# Altium schematic sheet ECU-3.SchDoc
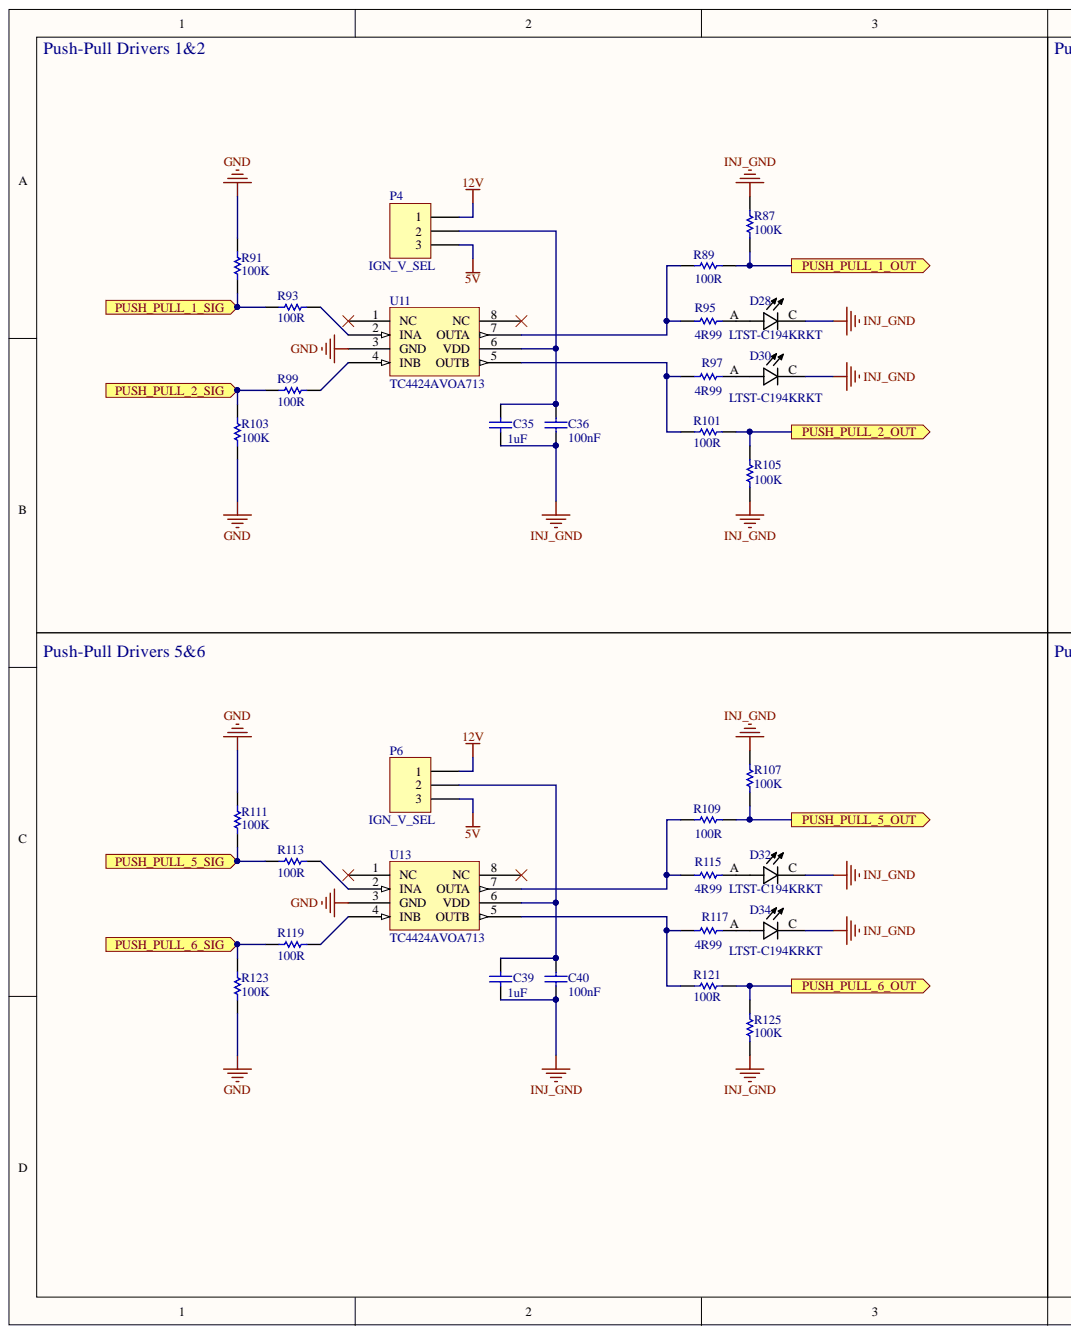
[diagram p0: ECU-3.SchDoc, left]
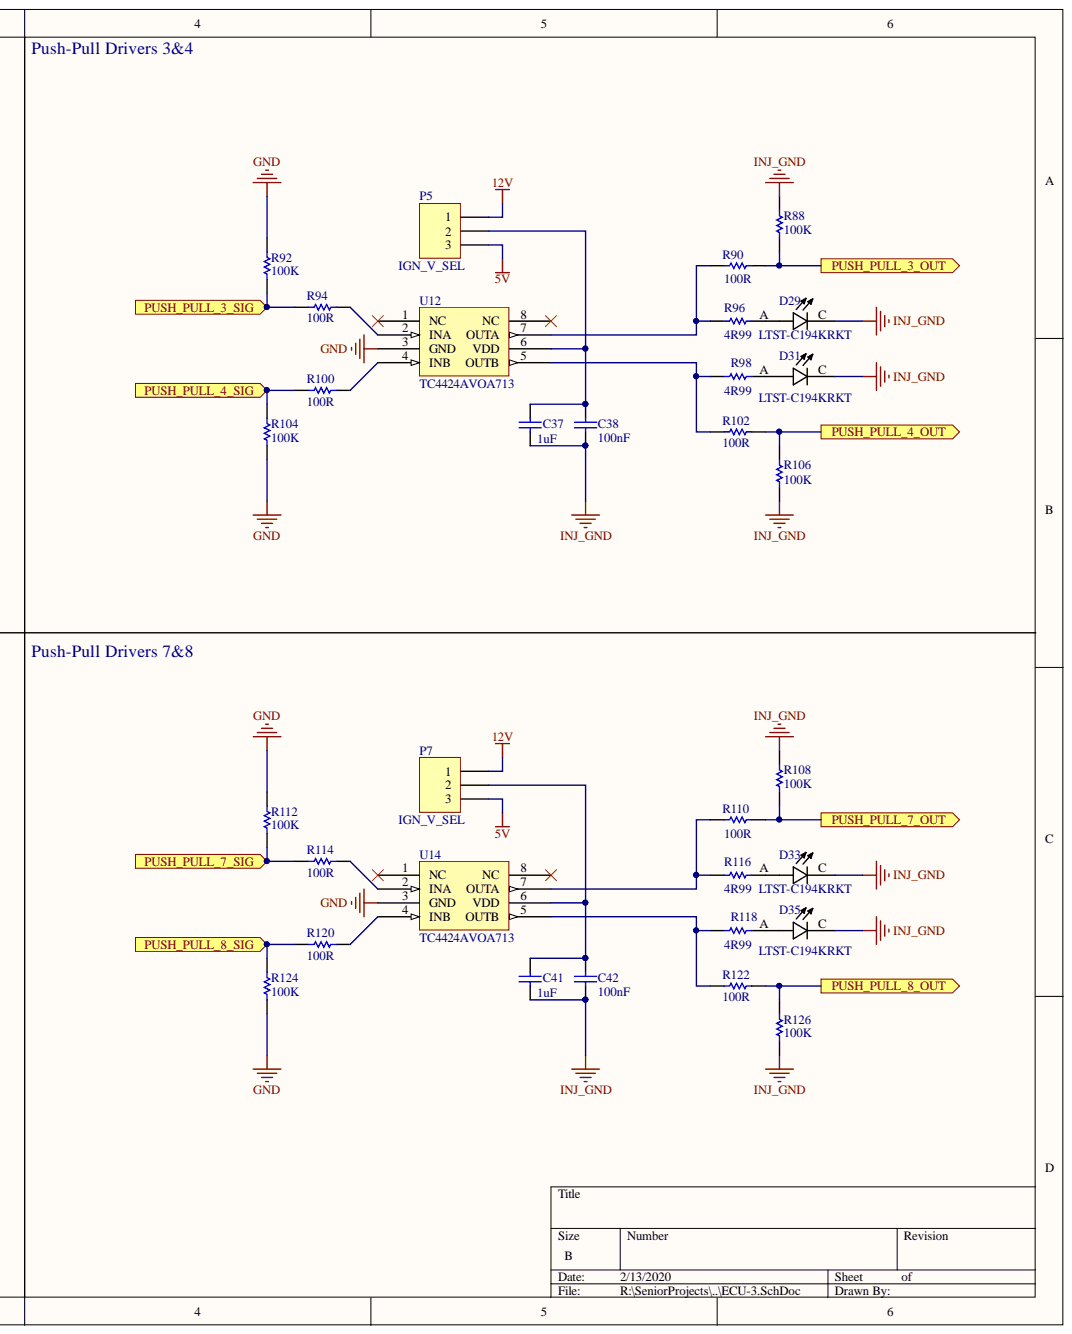
[diagram p1: ECU-3.SchDoc, right]

## Parts
C35  1uF  [CAPC0603(1608)100_L]
C36  100nF  [CAPC0603(1608)100_N]
C37  1uF  [CAPC0603(1608)100_L]
C38  100nF  [CAPC0603(1608)100_N]
C39  1uF  [CAPC0603(1608)100_L]
C40  100nF  [CAPC0603(1608)100_N]
C41  1uF  [CAPC0603(1608)100_L]
C42  100nF  [CAPC0603(1608)100_N]
D28  LTST-C194KRKT  [LEDC1608X35N_LTST-C194KRKT]
D29  LTST-C194KRKT  [LEDC1608X35N_LTST-C194KRKT]
D30  LTST-C194KRKT  [LEDC1608X35N_LTST-C194KRKT]
D31  LTST-C194KRKT  [LEDC1608X35N_LTST-C194KRKT]
D32  LTST-C194KRKT  [LEDC1608X35N_LTST-C194KRKT]
D33  LTST-C194KRKT  [LEDC1608X35N_LTST-C194KRKT]
D34  LTST-C194KRKT  [LEDC1608X35N_LTST-C194KRKT]
D35  LTST-C194KRKT  [LEDC1608X35N_LTST-C194KRKT]
P4  IGN_V_SEL  [HDR1X3]
P5  IGN_V_SEL  [HDR1X3]
P6  IGN_V_SEL  [HDR1X3]
P7  IGN_V_SEL  [HDR1X3]
R87  100K  [RESC0603(1608)_N]
R88  100K  [RESC0603(1608)_N]
R89  100R  [RESC0603(1608)_M]
R90  100R  [RESC0603(1608)_M]
R91  100K  [RESC0603(1608)_N]
R92  100K  [RESC0603(1608)_N]
R93  100R  [RESC0603(1608)_M]
R94  100R  [RESC0603(1608)_M]
R95  4R99  [RESC0603(1608)_M]
R96  4R99  [RESC0603(1608)_M]
R97  4R99  [RESC0603(1608)_M]
R98  4R99  [RESC0603(1608)_M]
R99  100R  [RESC0603(1608)_M]
R100  100R  [RESC0603(1608)_M]
R101  100R  [RESC0603(1608)_M]
R102  100R  [RESC0603(1608)_M]
R103  100K  [RESC0603(1608)_N]
R104  100K  [RESC0603(1608)_N]
R105  100K  [RESC0603(1608)_N]
R106  100K  [RESC0603(1608)_N]
R107  100K  [RESC0603(1608)_N]
R108  100K  [RESC0603(1608)_N]
R109  100R  [RESC0603(1608)_M]
R110  100R  [RESC0603(1608)_M]
R111  100K  [RESC0603(1608)_N]
R112  100K  [RESC0603(1608)_N]
R113  100R  [RESC0603(1608)_M]
R114  100R  [RESC0603(1608)_M]
R115  4R99  [RESC0603(1608)_M]
R116  4R99  [RESC0603(1608)_M]
R117  4R99  [RESC0603(1608)_M]
R118  4R99  [RESC0603(1608)_M]
R119  100R  [RESC0603(1608)_M]
R120  100R  [RESC0603(1608)_M]
R121  100R  [RESC0603(1608)_M]
R122  100R  [RESC0603(1608)_M]
R123  100K  [RESC0603(1608)_N]
R124  100K  [RESC0603(1608)_N]
R125  100K  [RESC0603(1608)_N]
R126  100K  [RESC0603(1608)_N]
U11  TC4424AVOA713  [TC4424AVOA713]
U12  TC4424AVOA713  [TC4424AVOA713]
U13  TC4424AVOA713  [TC4424AVOA713]
U14  TC4424AVOA713  [TC4424AVOA713]

## Ports
PUSH_PULL_1_OUT, PUSH_PULL_1_SIG, PUSH_PULL_2_OUT, PUSH_PULL_2_SIG, PUSH_PULL_3_OUT, PUSH_PULL_3_SIG, PUSH_PULL_4_OUT, PUSH_PULL_4_SIG, PUSH_PULL_5_OUT, PUSH_PULL_5_SIG, PUSH_PULL_6_OUT, PUSH_PULL_6_SIG, PUSH_PULL_7_OUT, PUSH_PULL_7_SIG, PUSH_PULL_8_OUT, PUSH_PULL_8_SIG

## Nets
5V: P4.3 P5.3 P6.3 P7.3
12V: P4.1 P5.1 P6.1 P7.1
GND: R91.1 R92.1 R103.1 R104.1 R111.1 R112.1 R123.1 R124.1 U11.3(GND) U12.3(GND) U13.3(GND) U14.3(GND)
INJ_GND: C35.1 C36.1 C37.1 C38.1 C39.1 C40.1 C41.1 C42.1 D28.C D29.C D30.C D31.C D32.C D33.C D34.C D35.C R87.2 R88.2 R105.1 R106.1 R107.2 R108.2 R125.1 R126.1
PUSH_PULL_1_OUT: R87.1 R89.2 ; port PUSH_PULL_1_OUT
PUSH_PULL_1_SIG: R91.2 R93.1 ; port PUSH_PULL_1_SIG
PUSH_PULL_2_OUT: R101.2 R105.2 ; port PUSH_PULL_2_OUT
PUSH_PULL_2_SIG: R99.1 R103.2 ; port PUSH_PULL_2_SIG
PUSH_PULL_3_OUT: R88.1 R90.2 ; port PUSH_PULL_3_OUT
PUSH_PULL_3_SIG: R92.2 R94.1 ; port PUSH_PULL_3_SIG
PUSH_PULL_4_OUT: R102.2 R106.2 ; port PUSH_PULL_4_OUT
PUSH_PULL_4_SIG: R100.1 R104.2 ; port PUSH_PULL_4_SIG
PUSH_PULL_5_OUT: R107.1 R109.2 ; port PUSH_PULL_5_OUT
PUSH_PULL_5_SIG: R111.2 R113.1 ; port PUSH_PULL_5_SIG
PUSH_PULL_6_OUT: R121.2 R125.2 ; port PUSH_PULL_6_OUT
PUSH_PULL_6_SIG: R119.1 R123.2 ; port PUSH_PULL_6_SIG
PUSH_PULL_7_OUT: R108.1 R110.2 ; port PUSH_PULL_7_OUT
PUSH_PULL_7_SIG: R112.2 R114.1 ; port PUSH_PULL_7_SIG
PUSH_PULL_8_OUT: R122.2 R126.2 ; port PUSH_PULL_8_OUT
PUSH_PULL_8_SIG: R120.1 R124.2 ; port PUSH_PULL_8_SIG
NetC35_2: C35.2 C36.2 P4.2 U11.6(VDD)
NetC37_2: C37.2 C38.2 P5.2 U12.6(VDD)
NetC39_2: C39.2 C40.2 P6.2 U13.6(VDD)
NetC41_2: C41.2 C42.2 P7.2 U14.6(VDD)
NetD28_A: D28.A R95.2
NetD29_A: D29.A R96.2
NetD30_A: D30.A R97.2
NetD31_A: D31.A R98.2
NetD32_A: D32.A R115.2
NetD33_A: D33.A R116.2
NetD34_A: D34.A R117.2
NetD35_A: D35.A R118.2
NetR89_1: R89.1 R95.1 U11.7(OUTA)
NetR90_1: R90.1 R96.1 U12.7(OUTA)
NetR93_2: R93.2 U11.2(INA)
NetR94_2: R94.2 U12.2(INA)
NetR97_1: R97.1 R101.1 U11.5(OUTB)
NetR98_1: R98.1 R102.1 U12.5(OUTB)
NetR99_2: R99.2 U11.4(INB)
NetR100_2: R100.2 U12.4(INB)
NetR109_1: R109.1 R115.1 U13.7(OUTA)
NetR110_1: R110.1 R116.1 U14.7(OUTA)
NetR113_2: R113.2 U13.2(INA)
NetR114_2: R114.2 U14.2(INA)
NetR117_1: R117.1 R121.1 U13.5(OUTB)
NetR118_1: R118.1 R122.1 U14.5(OUTB)
NetR119_2: R119.2 U13.4(INB)
NetR120_2: R120.2 U14.4(INB)

Not connected: U11 1(NC) 8(NC); U12 1(NC) 8(NC); U13 1(NC) 8(NC); U14 1(NC) 8(NC)

## Library data of the parts
C35 C37 C39 C41 = CMP-1035-02745-1: CAP 1uF 50V ±10% 0603 (1608 Metric) Thickness 1mm SMD; Case-EIA: 0603; Case-Metric: 1608; Tolerance: Â±10%; Component Kind: Standard; Library Name: Altium Content Vault; Max Thickness: 1 mm; Rated Voltage: 50 V; Technology: SMT; Value: 1uF
C36 C38 C40 C42 = CMP-1035-02592-1: CAP 100nF 50V ±10% 0603 (1608 Metric) Thickness 1mm SMD; Case-EIA: 0603; Case-Metric: 1608; Tolerance: Â±10%; Component Kind: Standard; Library Name: Altium Content Vault; Max Thickness: 1 mm; Rated Voltage: 50 V; Technology: SMT; Value: 100nF
D28-D35 = LTST-C194KRKT: Red 631nm LED Indication - Discrete 2V 0603 _1608 Metric_; MF: Lite-On Inc.; Component Kind: Standard; Digi-Key_Part_Number: 160-1835-1-ND; Digi-Key_Purchase_URL: https://www.digikey.com/product-detail/en/lite-on-inc/LTST-C194KRKT/160-1835-1-ND/2356232?utm_source=snapeda&utm_medium=aggregator&utm_campaign=symbol; Library Name: ECU.SchLib; MP: LTST-C194KRKT; Package: 0603 Lite-On; SnapEDA_Link: https://www.snapeda.com/parts/LTST-C194KRKT/Lite-On%20Inc./view-part/370565/?ref=snap
P4-P7 = Header 3: Header, 3-Pin; Component Kind: Standard; LatestRevisionDate: 17-Jul-2002; LatestRevisionNote: Re-released for DXP Platform.; Library Name: Miscellaneous Connectors.IntLib; Signal Integrity: Connector; Publisher: Altium Limited
R87 R88 R91 R92 R103-R108 R111 R112 R123-R126 = CMP-1012-00736-1: 100K 0.1W 1% 0603 (1608 Metric)  SMD; Case-EIA: 0603; Case-Metric: 1608; Value: 100000.00 Ohm; Component Kind: Standard; Library Name: Altium Content Vault; Power: 0.1 W; Technology: SMT; Tolerance: 1 %
R89 R90 R93 R94 R99-R102 R109 R110 R113 R114 R119-R122 = CMP-1012-00397-1: 100R 0.1W 1% 0603 (1608 Metric)  SMD; Case-EIA: 0603; Case-Metric: 1608; Value: 100.00 Ohm; Component Kind: Standard; Library Name: Altium Content Vault; Power: 0.1 W; Technology: SMT; Tolerance: 1 %
R95-R98 R115-R118 = CMP-1012-00250-1: 4R99 0.1W 1% 0603 (1608 Metric)  SMD; Case-EIA: 0603; Case-Metric: 1608; Value: 4.99 Ohm; Component Kind: Standard; Library Name: Altium Content Vault; Power: 0.1 W; Technology: SMT; Tolerance: 1 %
U11-U14 = TC4424AVOA713: Component Kind: Standard; Description: TC4424AVOA713; Library Name: ECU.SchLib
